# Altium schematic sheet Sheet2.SchDoc
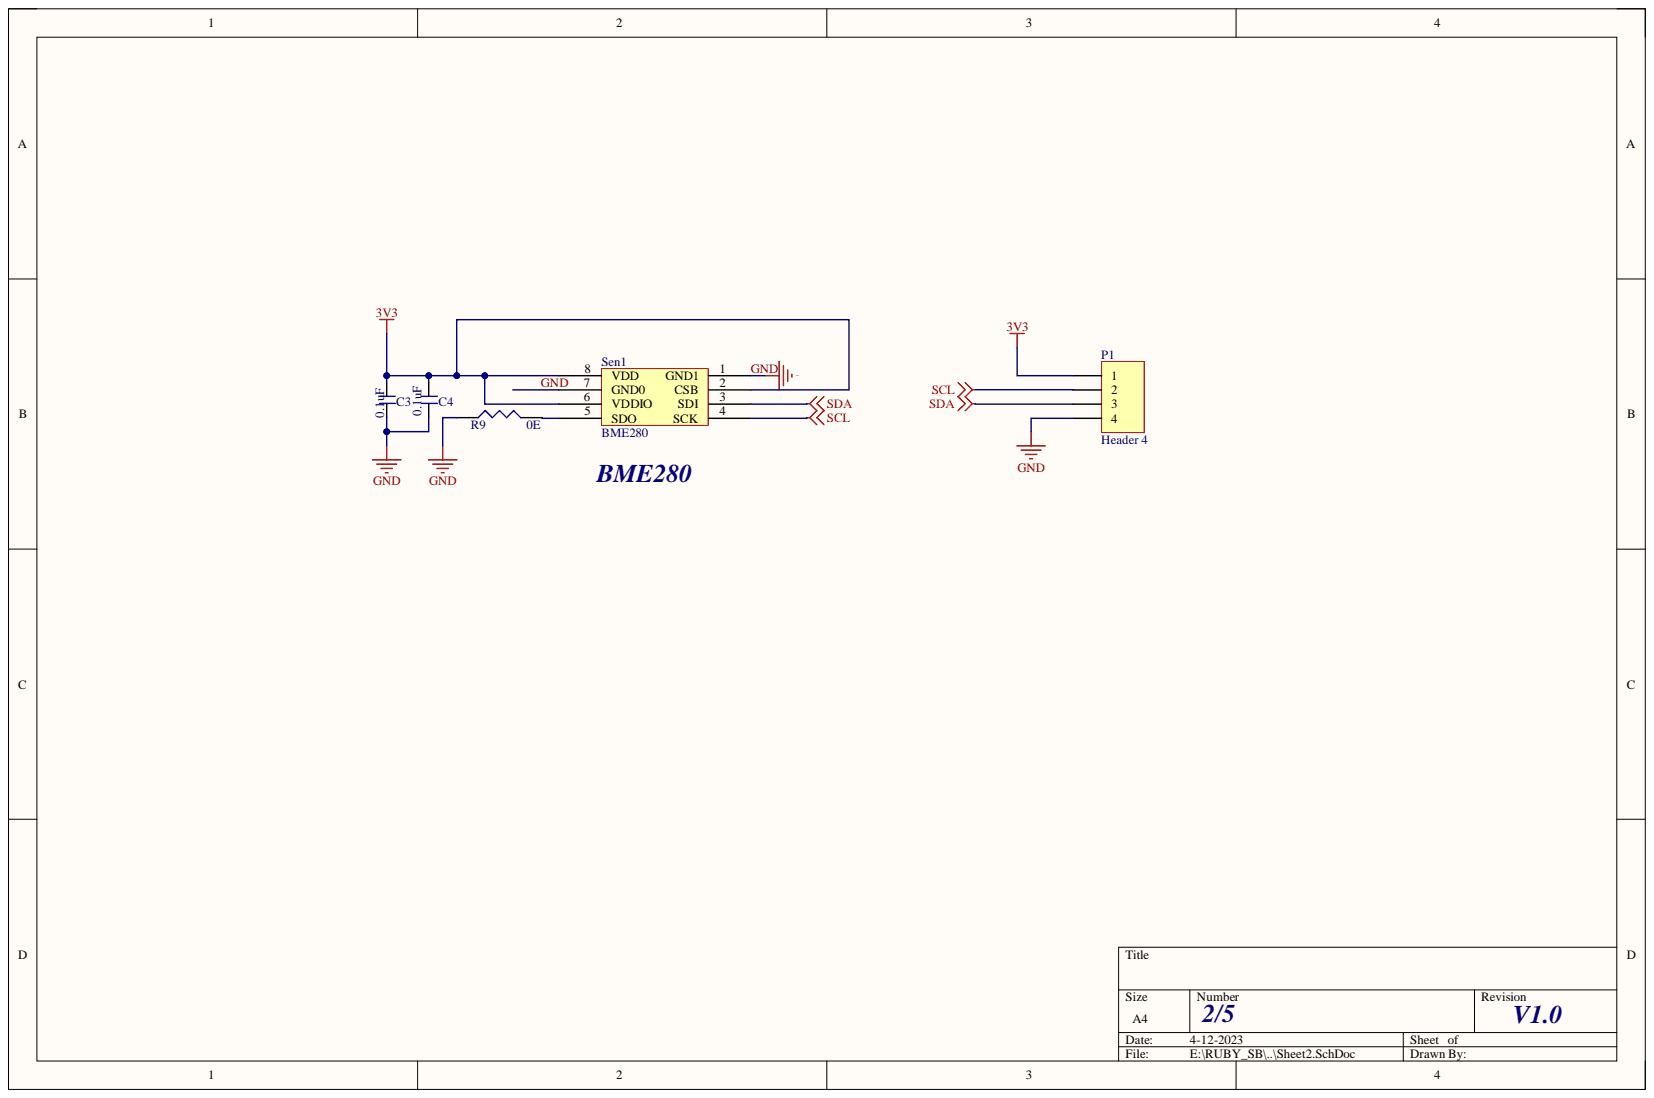
[diagram p0: Sheet2.SchDoc]

## Parts
C3  0.1uF  [C_0603]
C4  0.1uF  [C_0603]
P1  Header 4  [4P_TH_2.54MM]
R9  0E  [R_0603]
Sen1  BME280  [BME28]

## Nets
3V3: C3.2 C4.2 P1.1 Sen1.2(CSB) Sen1.6(VDDIO) Sen1.8(VDD)
SCL: P1.2 Sen1.4(SCK)
SDA: P1.3 Sen1.3(SDI)
GND: C3.1 C4.1 P1.4 R9.1 Sen1.1(GND1) Sen1.7(GND0)
NetR9_2: R9.2 Sen1.5(SDO)

## Library data of the parts
C3 C4 = CAP_NONPOLAR: Component Kind: Standard; Library Name: dxp_pasl_lib.IntLib
P1 = Header 4: Header, 4-Pin; Component Kind: Standard; LatestRevisionDate: 17-Jul-2002; LatestRevisionNote: Re-released for DXP Platform.; Library Name: Miscellaneous Connectors.IntLib; Signal Integrity: Connector; Publisher: Altium Limited
R9 = RES: Component Kind: Standard; Library Name: dxp_pasl_lib.IntLib
Sen1 = BME280: Digital humidity, pressure and temperature sensor 2.5mm X 2.5mm X 0.93mm metal lid LGA; Component Kind: Standard; Library Name: dxp_pasl_lib.IntLib
